# Altium schematic sheet NTC.SchDoc
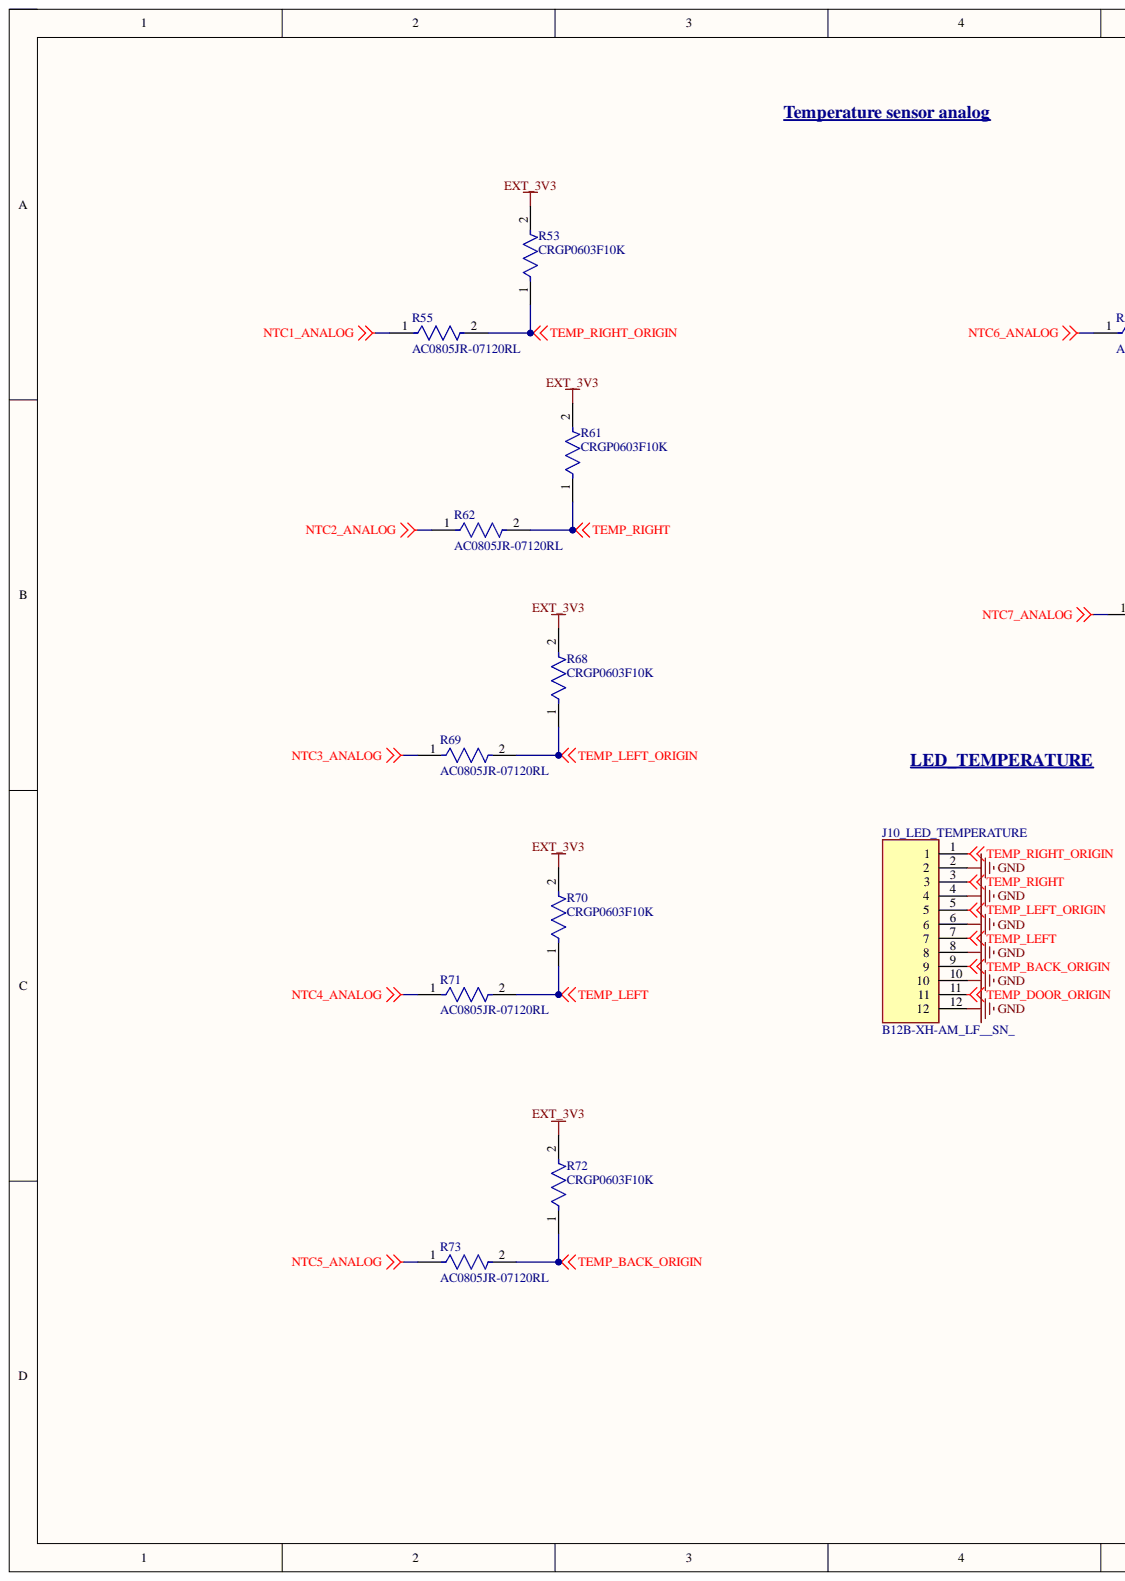
[diagram p0: NTC.SchDoc, left]
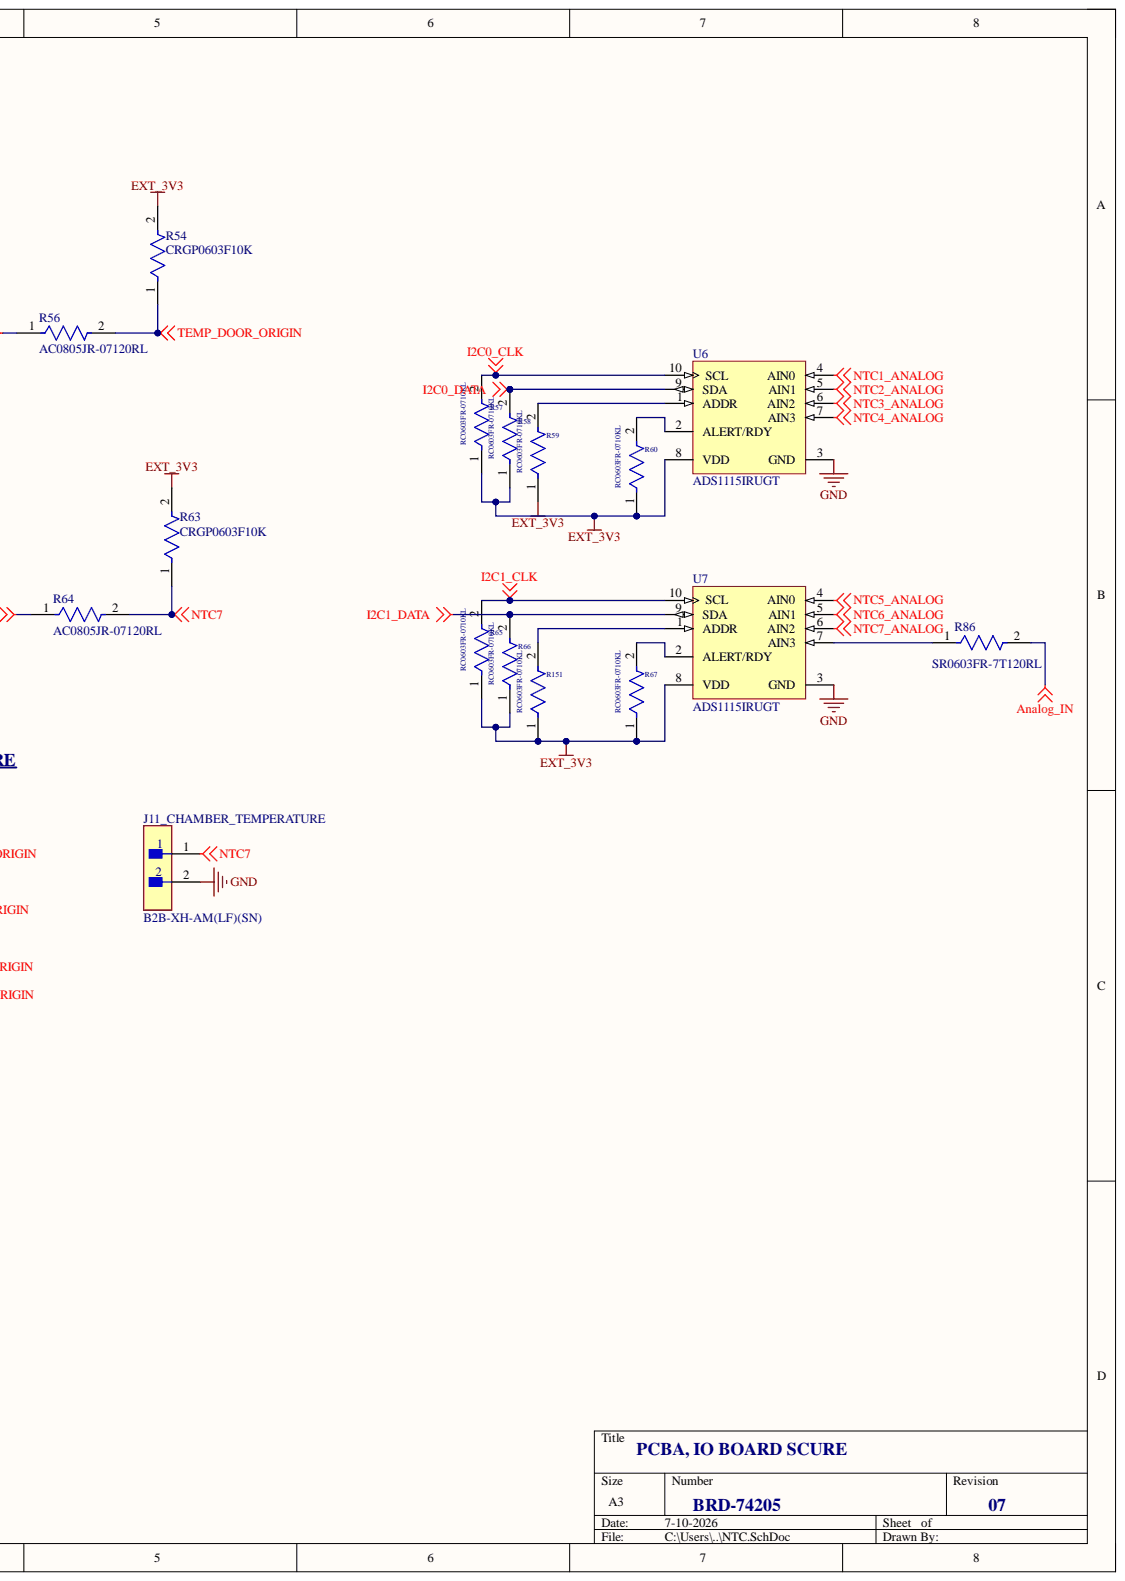
[diagram p1: NTC.SchDoc, right]

## Parts
J10_LED_TEMPERATURE  B12B-XH-AM_LF__SN_  [B12BXHAMLFSN]
J11_CHAMBER_TEMPERATURE  B2B-XH-AM(LF)(SN)  [FP-B2B-XH-AM_LF_SN-MFG]
R53  CRGP0603F10K  [FP-CRGP0603-IPC_C]
R54  CRGP0603F10K  [FP-CRGP0603-IPC_C]
R55  AC0805JR-07120RL  [FP-AC0805-MFG]
R56  AC0805JR-07120RL  [FP-AC0805-MFG]
R57  RC0603FR-0710KL  [FP-RC0603-0_55-IPC_C]
R58  RC0603FR-0710KL  [FP-RC0603-0_55-IPC_C]
R59  RC0603FR-0710KL  [FP-RC0603-0_55-IPC_C]
R60  RC0603FR-0710KL  [FP-RC0603-0_55-IPC_C]
R61  CRGP0603F10K  [FP-CRGP0603-IPC_C]
R62  AC0805JR-07120RL  [FP-AC0805-MFG]
R63  CRGP0603F10K  [FP-CRGP0603-IPC_C]
R64  AC0805JR-07120RL  [FP-AC0805-MFG]
R65  RC0603FR-0710KL  [FP-RC0603-0_55-IPC_C]
R66  RC0603FR-0710KL  [FP-RC0603-0_55-IPC_C]
R67  RC0603FR-0710KL  [FP-RC0603-0_55-IPC_C]
R68  CRGP0603F10K  [FP-CRGP0603-IPC_C]
R69  AC0805JR-07120RL  [FP-AC0805-MFG]
R70  CRGP0603F10K  [FP-CRGP0603-IPC_C]
R71  AC0805JR-07120RL  [FP-AC0805-MFG]
R72  CRGP0603F10K  [FP-CRGP0603-IPC_C]
R73  AC0805JR-07120RL  [FP-AC0805-MFG]
R86  SR0603FR-7T120RL  [FP-SR0603-MFG]
R151  RC0603FR-0710KL  [FP-RC0603-0_55-IPC_C]
U6  ADS1115IRUGT  [TI-RUG10_V]
U7  ADS1115IRUGT  [TI-RUG10_V]

## Nets
Analog_IN: R86.2
EXT_3V3: R53.2 R54.2 R57.1 R58.1 R59.1 R60.1 R61.2 R63.2 R65.1 R66.1 R67.1 R68.2 R70.2 R72.2 R151.1 U6.8(VDD) U7.8(VDD)
GND: J10_LED_TEMPERATURE.2 J10_LED_TEMPERATURE.4 J10_LED_TEMPERATURE.6 J10_LED_TEMPERATURE.8 J10_LED_TEMPERATURE.10 J10_LED_TEMPERATURE.12 J11_CHAMBER_TEMPERATURE.2 U6.3(GND) U7.3(GND)
I2C0_CLK: R57.2 U6.10(SCL)
I2C0_DATA: R58.2 U6.9(SDA)
I2C1_CLK: R65.2 U7.10(SCL)
I2C1_DATA: R66.2 U7.9(SDA)
NTC1_ANALOG: R55.1 U6.4(AIN0)
NTC2_ANALOG: R62.1 U6.5(AIN1)
NTC3_ANALOG: R69.1 U6.6(AIN2)
NTC4_ANALOG: R71.1 U6.7(AIN3)
NTC5_ANALOG: R73.1 U7.4(AIN0)
NTC6_ANALOG: R56.1 U7.5(AIN1)
NTC7: J11_CHAMBER_TEMPERATURE.1 R63.1 R64.2
NTC7_ANALOG: R64.1 U7.6(AIN2)
TEMP_BACK_ORIGIN: J10_LED_TEMPERATURE.9 R72.1 R73.2
TEMP_DOOR_ORIGIN: J10_LED_TEMPERATURE.11 R54.1 R56.2
TEMP_LEFT: J10_LED_TEMPERATURE.7 R70.1 R71.2
TEMP_LEFT_ORIGIN: J10_LED_TEMPERATURE.5 R68.1 R69.2
TEMP_RIGHT: J10_LED_TEMPERATURE.3 R61.1 R62.2
TEMP_RIGHT_ORIGIN: J10_LED_TEMPERATURE.1 R53.1 R55.2
NetR59_2: R59.2 U6.1(ADDR)
NetR60_2: R60.2 U6.2(ALERT/RDY)
NetR67_2: R67.2 U7.2(ALERT/RDY)
NetR86_1: R86.1 U7.7(AIN3)
NetR151_2: R151.2 U7.1(ADDR)

## Library data of the parts
J10_LED_TEMPERATURE = B12B-XH-AM_LF__SN_: Connector; Manufacturer_Name: JST (JAPAN SOLDERLESS TERMINALS); Manufacturer_Part_Number: B12B-XH-AM(LF)(SN); Component Kind: Standard; Datasheet Link: http://www.jst-mfg.com/product/pdf/eng/eXH.pdf; Height: 7mm; Mouser Part Number: 306-B12BXHAMLFSN; Mouser Price/Stock: https://www.mouser.co.uk/ProductDetail/JST-Commercial/B12B-XH-AMLFSN?qs=cdbOS8ANM9A1AXrbo968JA%3D%3D
J11_CHAMBER_TEMPERATURE = CMP-17439-000037-1: CONN HEADER VERT 2POS 2.5MM; Connector Type: Male Pin; Contact Resistance: 20mR; Max Current Rating: 3A; Max Voltage Rating (AC): 250V; Number of Positions: 2; Color: Green; Component Kind: Standard; Contact Material: Brass; Contact Plating: Tin; Contact Type: Male Pin; Depth: 5.75mm; Fastening Type: Detent; Housing Color: White; Housing Material: Polyamide; Lead Free: Lead Free; Length: 7.4mm; Library Name: Altium Content Vault; Max Operating Temperature: 85°C; Min Operating Temperature: -25°C; Mount: Through Hole; Number of Rows: 1; Orientation: Straight; Packaging: Bulk; Pitch: 2.5mm; Series: XH; Termination: Solder
R53 R54 R61 R63 R68 R70 R72 = CMP-03211-004414-1: CRGP 0603 10K 1%; Manufacturer: TE; Power Rating: 0.25W; Resistance: 10KΩ; Temperature Coefficient: ±100ppm/°C; Tolerance: 0.01; Value: 10KΩ; Voltage Rating: 50V; Ambient Temperature Range High: +70°C; Automotive: No; Category: Res; Component Kind: Standard; ComponentLink2Description: Datasheet; ComponentLink2URL: https://www.te.com/commerce/DocumentDelivery/DDEController?Action=srchrtrv&DocNm=9-1773463-9&DocType=DS&DocLang=English; Composition: Thick Film; Device Class L1: Passive Components; Device Class L2: Resistors; Device Class L3: Chip SMD Resistors; Digikey Description: CRGP 0603 10K 1%; Height: 0.55mm; Ipc Land Pattern Name: RESC16080X45; Lead Free: Yes; Library Name: Altium Content Vault; Manufacturer Part Number: CRGP0603F10K; Mouser Description: Res, 10K, 1%, 0.25W, 0603, Thick Film; Octopart: https://octopart.com/crgp0603f10k-te+connectivity-91018721; Package: CRGP0603; RoHS: TRUE; Series: CRGP; Temperature: -55°C to +155°C; Temperature Range High: +155°C; Temperature Range Low: -55°C
R55 R56 R62 R64 R69 R71 R73 = CMP-03412-037390-1: RES SMD 120 OHM 5% 1/8W 0805; Manufacturer: Yageo; Automotive Grade: Grade 0; Resistance: 120Ω; Tolerance: 0.05; Value: 120Ω; Voltage Rating: 150V; Automotive: Yes; Category: Res; Component Kind: Standard; ComponentLink2Description: Datasheet; ComponentLink2URL: http://www.yageo.com/documents/recent/PYu-AC_51_RoHS_L_6.pdf; Composition: Thick Film; Device Class L1: Passive Components; Device Class L2: Resistors; Device Class L3: Chip SMD Resistors; Digikey Description: RES SMD 120 OHM 5% 1/8W 0805; Footprint Url: http://www.yageo.com/exep/pages/download/literatures/PYu-R_Mount_10.pdf; Height: 0.6mm; Ipc Land Pattern Name: RESC200125X50; Lead Free: Yes; Library Name: Altium Content Vault; Manufacturer Part Number: AC0805JR-07120RL; Mouser Description: Thick Film Resistors - SMD 1/8W 120ohm 5% AEC-Q200; Octopart: https://octopart.com/ac0805jr-07120rl-yageo-52448213; Package: AC0805; Power Rating: 1/8W; RoHS: TRUE; Series: AC; Temperature: -55°C to +155°C; Temperature Range High: +155°C; Temperature Range Low: -55°C
R57-R60 R65-R67 R151 = CMP-2100-03664-2: RES 10K OHM 1% 1/10W 0603; Max Power Dissipation: 100mW; Resistance: 10kR; Temperature Coefficient: 100ppm/°C; Voltage Rating: 75V; Voltage Rating (DC): 50V; Case Code (Imperial): 0603; Case Code (Metric): 1608; Case/Package: 0603; Component Kind: Standard; Construction: Rectangular; Depth: 0.8mm; Features: Moisture Resistant; Height: 0.45mm; Lead Free: Lead Free; Lead Pitch: 0.3mm; Length: 1.6mm; Library Name: Altium Content Vault; Max Operating Temperature: 155°C; Military Standard: Not; Min Operating Temperature: -55°C; Number of Pins: 2; Number of Terminations: 2; Packaging: Tape and Reel; Power Rating: 100mW; Resistance Tolerance: 1%; Resistor Type: Thick Film; RoHS Compliant: Yes; Series: RC; Size Code: 0603; Termination: SMD/SMT; Tolerance: 1%; Weight: 7.1E-05oz; Width: 0.8mm
R86 = CMP-03412-000681-1: RES SMD 0603 1/4W 1% 120 OHM; Manufacturer: Yageo; Automotive Grade: Grade 0; Power Rating: 0.25W; Resistance: 120Ω; Tolerance: 0.01; Value: 120Ω; Voltage Rating: 75V; Automotive: Yes; Category: Res; Component Kind: Standard; ComponentLink2Description: Datasheet; ComponentLink2URL: http://www.yageo.com/documents/recent/PYu-SR_D_F%20tol_RoHS_L_3.pdf; Composition: Thick Film; Device Class L1: Passive Components; Device Class L2: Resistors; Device Class L3: Chip SMD Resistors; Digikey Description: RES SMD 0603 1/4W 1% 120 OHM; Footprint Url: http://www.yageo.com/exep/pages/download/literatures/PYu-R_Mount_10.pdf; Height: 0.55mm; Ipc Land Pattern Name: RESC16080X45; Lead Free: Yes; Library Name: Altium Content Vault; Manufacturer Part Number: SR0603FR-7T120RL; Mouser Description: Surge Chip Resistor Automotive Grade Thick Film 0603 120Ohm 1% Paper T/R; Octopart: https://octopart.com/sr0603fr-7t120rl-yageo-92673849; Package: SR0603; RoHS: TRUE; Series: SR; Temperature: -55°C to +155°C; Temperature Range High: +155°C; Temperature Range Low: -55°C
U6 U7 = CMP-0003-00971-1: 16-Bit ADC with Integrated Multiplexer, PGA, Comparator, Oscillator, and Reference, -40 to 125 degC, 10-pin HandDrawn (RUG10), Green (RoHS & no Sb/Br); Manufacturer: Texas Instruments; # Input Channels: 4; Analog Voltage AV/DD(Max)(V): 5.5; Analog Voltage AV/DD(Min)(V): 2; Architecture: Delta-Sigma; INL(Max)(+/-LSB): 1; Interface: Serial I2C; Resolution(Bits): 16; Sample Rate (max)(SPS): 860; SubFamily: Precision ADC (<=10MSPS); Code_JEDEC: MO-288; Component Kind: Standard; ComponentLink1Description: Manufacturer URL; ComponentLink1URL: http://www.ti.com/; ComponentLink2Description: Datasheet; ComponentLink2URL: http://www.ti.com/general/docs/lit/getliterature.tsp?genericPartNumber=ADS1115&fileType=pdf; ComponentLink3Description: Package Specification; ComponentLink3URL: http://www.ti.com/litv/pdf/mpqf216a; DatasheetVersion: SBAS444B; Digital Supply(Max)(V): 5.5; Digital Supply(Min)(V): 2; Eco-Plan: Green (RoHS & no Sb/Br); Library Name: Altium Content Vault; Mounting Technology: Surface Mount; PackageDescription: 10-Pin Plastic Quad Flatpack, Body 1.5 x 2 mm, Pitch 0.5 mm; PackageReference: RUG10; PackageVersion: 4208528-3/B, 04/2008; PartNumber: ADS1115IRUGT
